# Altium schematic sheet SNES TST Sheet2.SchDoc
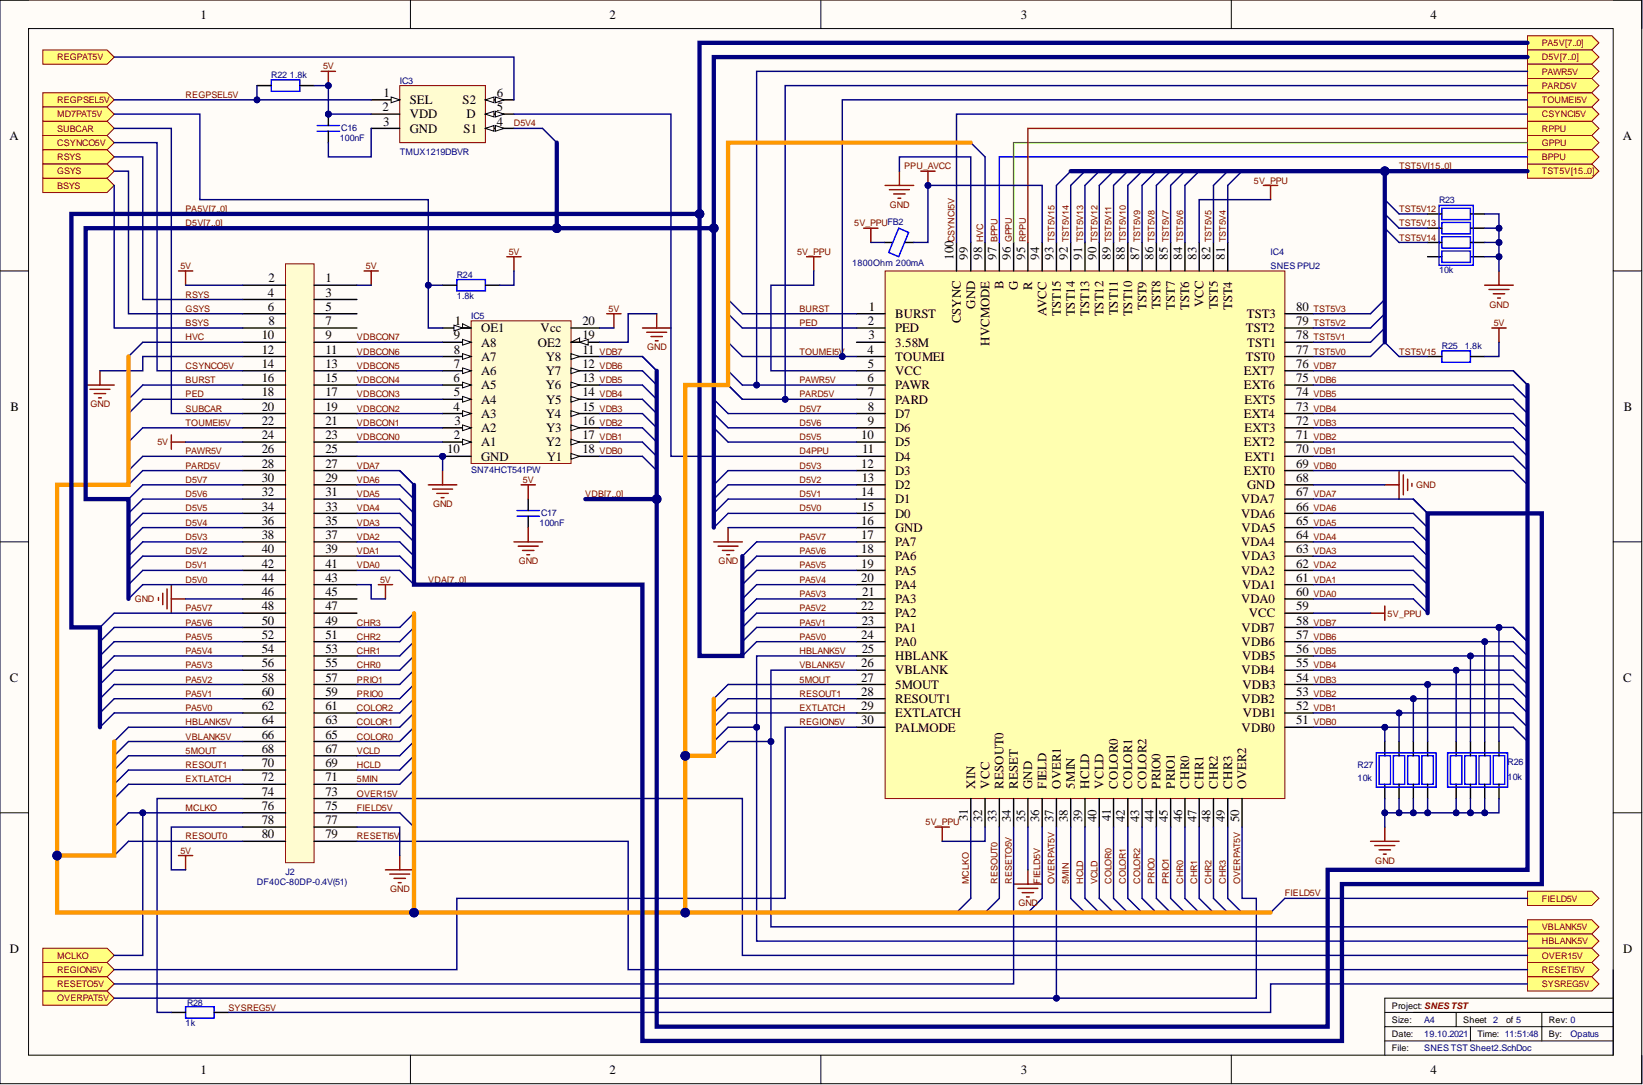
[diagram p0: SNES TST Sheet2.SchDoc]

## Parts
C16  100nF  [Cap_0402_Chip]
C17  100nF  [Cap_0402_Chip]
FB2  1800Ohm  200mA  [Ind_0402_Chip]
IC3  TMUX1219  Manufacturer_Part_Number: TMUX1219DBVR  [SOT23-6]
IC4  SNES PPU2  [PPU100Pin]  (no BOM)
IC5  SN74HCT541  Manufacturer_Part_Number: SN74HCT541PW  [TSSOP20 Narrow]
J2  Manufacturer_Part_Number: DF40C-80DP-0.4V(51)  [DF40C-80DP]  (hidden comment: 80Pole Connector)
R22  1.8k  [Res_0402_Chip]
R23  10k  [1206_Array_Chip]
R24  1.8k  [Res_0402_Chip]
R25  1.8k  [Res_0402_Chip]
R26  10k  [1206_Array_Chip]
R27  10k  [1206_Array_Chip]
R28  1k  [Res_0402_Chip]

## Ports
BPPU, BSYS, CSYNCI5V, CSYNCO5V, D5V0, D5V1, D5V2, D5V3, D5V4, D5V5, D5V6, D5V7, D5V[7..0], FIELD5V, GPPU, GSYS, HBLANK5V, MCLKO, MD7PAT5V, OVER15V, OVERPAT5V, PA5V0, PA5V1, PA5V2, PA5V3, PA5V4, PA5V5, PA5V6, PA5V7, PA5V[7..0], PARD5V, PAWR5V, REGION5V, REGPAT5V, REGPSEL5V, RESETI5V, RESETO5V, RPPU, RSYS, SUBCAR, SYSREG5V, TOUMEI5V, TST5V0, TST5V1, TST5V2, TST5V3, TST5V4, TST5V5, TST5V6, TST5V7, TST5V8, TST5V9, TST5V10, TST5V11, TST5V12, TST5V13, TST5V14, TST5V15, TST5V[15..0], VBLANK5V

## Nets
5V: C16.1 C17.1 IC3.2(VDD) IC5.20(Vcc) J2.1 J2.2 J2.24 J2.43 J2.78 R22.1 R24.1 R25.1
5V_PPU: FB2.1 IC4.5(VCC) IC4.32(VCC) IC4.59(VCC) IC4.83(VCC)
GND: C17.2 IC4.16(GND) IC4.35(GND) IC4.68(GND) IC4.99(GND) IC5.10(GND) IC5.19(OE2) J2.12 J2.25 J2.46 J2.77 R23.1 R23.2 R23.3 R23.4 R26.1 R26.2 R26.3 R26.4 R27.1 R27.2 R27.3 R27.4
PPU_AVCC: FB2.2 IC4.94(AVCC)
5MIN: IC4.38(5MIN) J2.71
5MOUT: IC4.27(5MOUT) J2.68
BPPU: IC4.97(B) ; port BPPU
BSYS: J2.8 ; port BSYS
BURST: IC4.1(BURST) J2.16
CHR0: IC4.46(CHR0) J2.55
CHR1: IC4.47(CHR1) J2.53
CHR2: IC4.48(CHR2) J2.51
CHR3: IC4.49(CHR3) J2.49
COLOR0: IC4.41(COLOR0) J2.65
COLOR1: IC4.42(COLOR1) J2.63
COLOR2: IC4.43(COLOR2) J2.61
CSYNCI5V: IC4.100(CSYNC) ; port CSYNCI5V
CSYNCO5V: J2.14 ; port CSYNCO5V
D4PPU: IC3.5(D) IC4.11(D4)
D5V0: IC4.15(D0) J2.44 ; port D5V[7..0]  [also labelled D5V]
D5V1: IC4.14(D1) J2.42 ; port D5V[7..0]  [also labelled D5V]
D5V2: IC4.13(D2) J2.40 ; port D5V[7..0]  [also labelled D5V]
D5V3: IC4.12(D3) J2.38 ; port D5V[7..0]  [also labelled D5V]
D5V4: IC3.4(S1) J2.36 ; port D5V[7..0]  [also labelled D5V]
D5V5: IC4.10(D5) J2.34 ; port D5V[7..0]  [also labelled D5V]
D5V6: IC4.9(D6) J2.32 ; port D5V[7..0]  [also labelled D5V]
D5V7: IC4.8(D7) J2.30 ; port D5V[7..0]  [also labelled D5V]
EXTLATCH: IC4.29(EXTLATCH) J2.72
FIELD5V: IC4.36(FIELD) J2.75 ; port FIELD5V
GPPU: IC4.96(G) ; port GPPU
GSYS: J2.6 ; port GSYS
HBLANK5V: IC4.25(HBLANK) J2.64 ; port HBLANK5V
HCLD: IC4.39(HCLD) J2.69
HVC: IC4.98(HVCMODE) J2.10
MCLKO: IC4.31(XIN) J2.76 ; port MCLKO
MD7PAT5V: IC5.1(OE1) R24.2 ; port MD7PAT5V
OVER15V: J2.73 ; port OVER15V
OVERPAT5V: IC4.37(OVER1) IC4.50(OVER2) ; port OVERPAT5V
PA5V0: IC4.24(PA0) J2.62 ; port PA5V[7..0]  [also labelled PA5V]
PA5V1: IC4.23(PA1) J2.60 ; port PA5V[7..0]  [also labelled PA5V]
PA5V2: IC4.22(PA2) J2.58 ; port PA5V[7..0]  [also labelled PA5V]
PA5V3: IC4.21(PA3) J2.56 ; port PA5V[7..0]  [also labelled PA5V]
PA5V4: IC4.20(PA4) J2.54 ; port PA5V[7..0]  [also labelled PA5V]
PA5V5: IC4.19(PA5) J2.52 ; port PA5V[7..0]  [also labelled PA5V]
PA5V6: IC4.18(PA6) J2.50 ; port PA5V[7..0]  [also labelled PA5V]
PA5V7: IC4.17(PA7) J2.48 ; port PA5V[7..0]  [also labelled PA5V]
PARD5V: IC4.7(PARD) J2.28 ; port PARD5V
PAWR5V: IC4.6(PAWR) J2.26 ; port PAWR5V
PED: IC4.2(PED) J2.18
PRIO0: IC4.44(PRIO0) J2.59
PRIO1: IC4.45(PRIO1) J2.57
REGION5V: IC4.30(PALMODE) ; port REGION5V
REGPAT5V: IC3.6(S2) ; port REGPAT5V
REGPSEL5V: IC3.1(SEL) R22.2 ; port REGPSEL5V
RESETI5V: J2.79 ; port RESETI5V
RESETO5V: IC4.34(RESET) ; port RESETO5V
RESOUT0: IC4.33(RESOUT0) J2.80
RESOUT1: IC4.28(RESOUT1) J2.70
RPPU: IC4.95(R) ; port RPPU
RSYS: J2.4 ; port RSYS
SUBCAR: J2.20 ; port SUBCAR
SYSREG5V: R28.1 ; port SYSREG5V
TOUMEI5V: IC4.4(TOUMEI) J2.22 ; port TOUMEI5V
TST5V0: IC4.77(TST0) ; port TST5V[15..0]  [also labelled TST5V]
TST5V1: IC4.78(TST1) ; port TST5V[15..0]  [also labelled TST5V]
TST5V2: IC4.79(TST2) ; port TST5V[15..0]  [also labelled TST5V]
TST5V3: IC4.80(TST3) ; port TST5V[15..0]  [also labelled TST5V]
TST5V4: IC4.81(TST4) ; port TST5V[15..0]  [also labelled TST5V]
TST5V5: IC4.82(TST5) ; port TST5V[15..0]  [also labelled TST5V]
TST5V6: IC4.84(TST6) ; port TST5V[15..0]  [also labelled TST5V]
TST5V7: IC4.85(TST7) ; port TST5V[15..0]  [also labelled TST5V]
TST5V8: IC4.86(TST8) ; port TST5V[15..0]  [also labelled TST5V]
TST5V9: IC4.87(TST9) ; port TST5V[15..0]  [also labelled TST5V]
TST5V10: IC4.88(TST10) ; port TST5V[15..0]  [also labelled TST5V]
TST5V11: IC4.89(TST11) ; port TST5V[15..0]  [also labelled TST5V]
TST5V12: IC4.90(TST12) R23.5 ; port TST5V[15..0]  [also labelled TST5V]
TST5V13: IC4.91(TST13) R23.6 ; port TST5V[15..0]  [also labelled TST5V]
TST5V14: IC4.92(TST14) R23.7 ; port TST5V[15..0]  [also labelled TST5V]
TST5V15: IC4.93(TST15) R25.2 ; port TST5V[15..0]  [also labelled TST5V]
VBLANK5V: IC4.26(VBLANK) J2.66 ; port VBLANK5V
VCLD: IC4.40(VCLD) J2.67
VDA0: IC4.60(VDA0) J2.41  [also labelled VDA]
VDA1: IC4.61(VDA1) J2.39  [also labelled VDA]
VDA2: IC4.62(VDA2) J2.37  [also labelled VDA]
VDA3: IC4.63(VDA3) J2.35  [also labelled VDA]
VDA4: IC4.64(VDA4) J2.33  [also labelled VDA]
VDA5: IC4.65(VDA5) J2.31  [also labelled VDA]
VDA6: IC4.66(VDA6) J2.29  [also labelled VDA]
VDA7: IC4.67(VDA7) J2.27  [also labelled VDA]
VDB0: IC4.51(VDB0) IC4.69(EXT0) IC5.18(Y1) R27.8  [also labelled VDB]
VDB1: IC4.52(VDB1) IC4.70(EXT1) IC5.17(Y2) R27.7  [also labelled VDB]
VDB2: IC4.53(VDB2) IC4.71(EXT2) IC5.16(Y3) R27.6  [also labelled VDB]
VDB3: IC4.54(VDB3) IC4.72(EXT3) IC5.15(Y4) R27.5  [also labelled VDB]
VDB4: IC4.55(VDB4) IC4.73(EXT4) IC5.14(Y5) R26.8  [also labelled VDB]
VDB5: IC4.56(VDB5) IC4.74(EXT5) IC5.13(Y6) R26.7  [also labelled VDB]
VDB6: IC4.57(VDB6) IC4.75(EXT6) IC5.12(Y7) R26.6  [also labelled VDB]
VDB7: IC4.58(VDB7) IC4.76(EXT7) IC5.11(Y8) R26.5  [also labelled VDB]
VDBCON0: IC5.2(A1) J2.23
VDBCON1: IC5.3(A2) J2.21
VDBCON2: IC5.4(A3) J2.19
VDBCON3: IC5.5(A4) J2.17
VDBCON4: IC5.6(A5) J2.15
VDBCON5: IC5.7(A6) J2.13
VDBCON6: IC5.8(A7) J2.11
VDBCON7: IC5.9(A8) J2.9
NetC16_2: C16.2 IC3.3(GND)
NetJ2_74: J2.74 R28.2

Not connected: IC4 3(3.58M); J2 3 5 7 45 47; R23 8

## Library data of the parts
C16 C17 = Capacitor: Dielectric: X7R; Nominal Voltage: 10V; Tolerance: +-20%; Component Kind: Standard; Library Name: PCB.SchLib; Value: 100nF
FB2 = Ferrite Bead: Manufacturer_Part_Number: MMZ1005S182ET000; Vendor: TDK; Component Kind: Standard; Library Name: PCB.SchLib; Value: 1800Ohm  200mA
IC3 = TMUX1219: 2:1 MUX; Vendor: Texas Instruments; Component Kind: Standard; Library Name: PCB.SchLib
IC4 = PPU2100Pin: PPU2; Vendor: Nintendo; Library Name: PCB.SchLib; Manufacturer_Part_Number: PPU2
IC5 = SN74HCT541: Vendor: Texas Instruments; Component Kind: Standard; Library Name: PCB.SchLib
J2 = Conn 80P: Vendor: Hirose Connector; Component Kind: Standard; Description: 80Pole Connector; Library Name: PCB.SchLib
R22 R24 R25 R28 = R: Tolerance: +-1%; Component Kind: Standard; Library Name: PCB.SchLib
  R22 R24 R25: Value: 1.8k
  R28: Value: 1k
R23 R26 R27 = RNetwork: Tolerance: +-1%; Component Kind: Standard; Library Name: PCB.SchLib; Value: 10k
